# Altium schematic sheet CPUBoard_p3.SchDoc
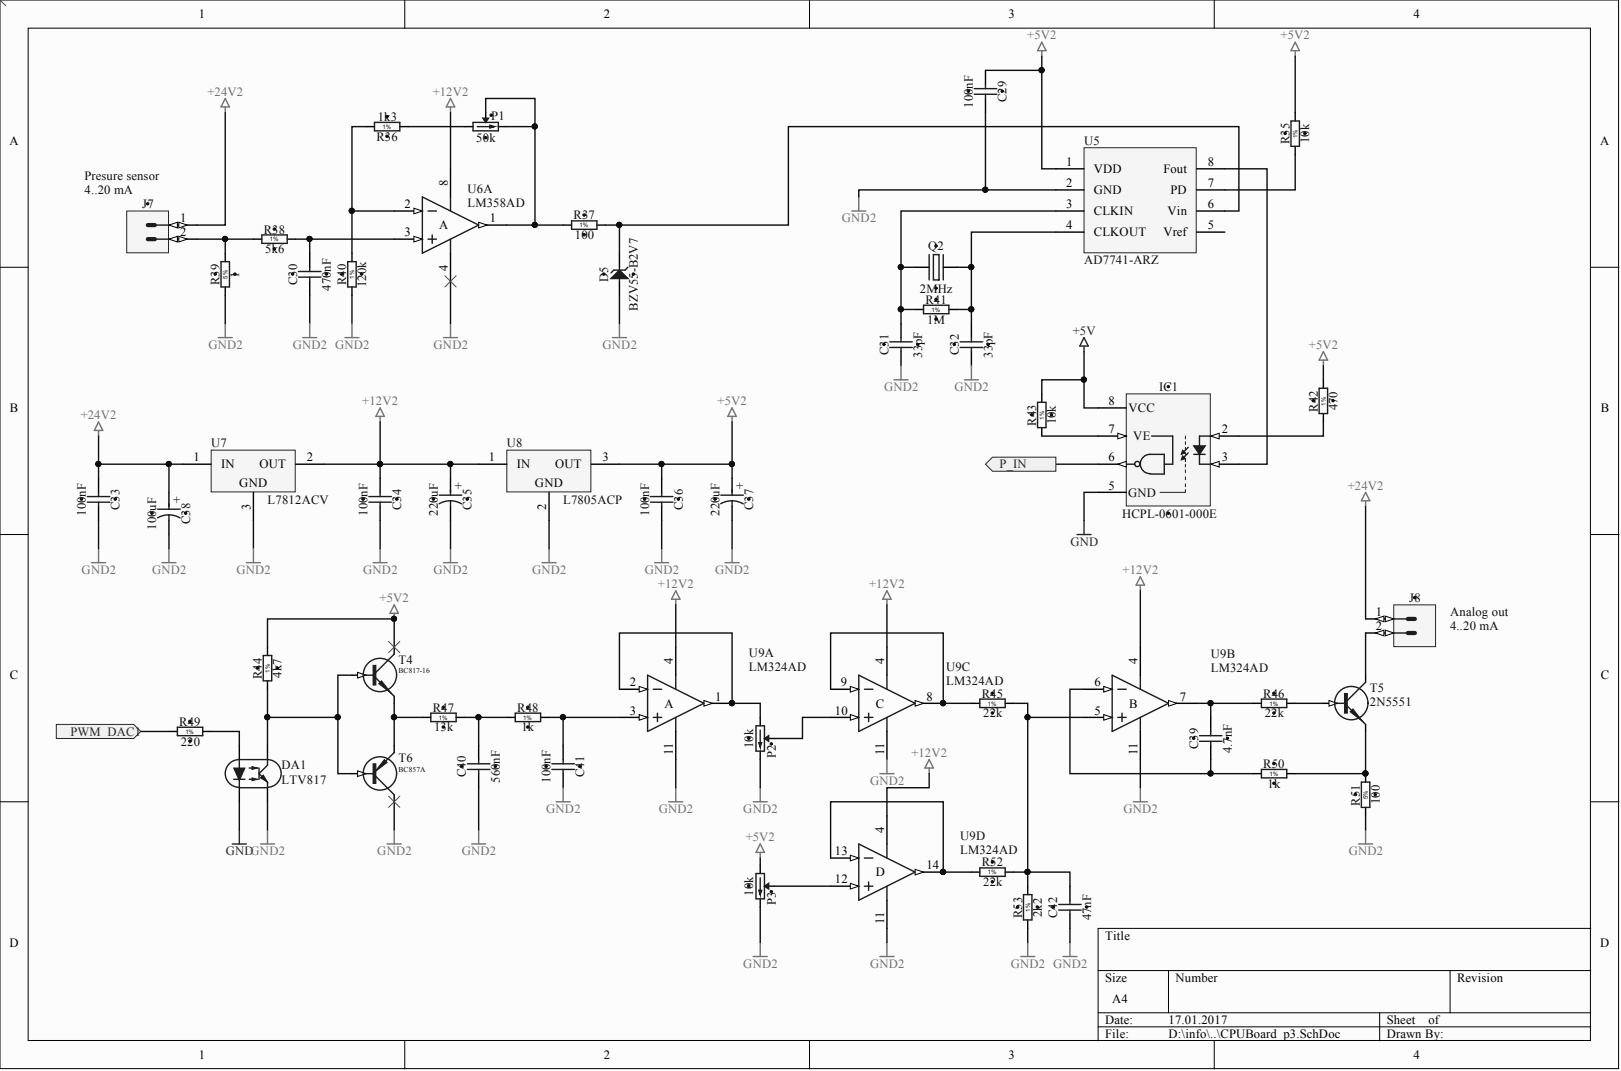
[diagram p0: CPUBoard_p3.SchDoc]

## Parts
C29  100nF  [CAPC2012X135N]
C30  470nF  [CAPC2012X110N]
C31  33pF  [CAPC2012X135N]
C32  33pF  [CAPC2012X135N]
C33  100nF  [CAPC2012X135N]
C34  100nF  [CAPC2012X135N]
C35  220uF  [CAPPR350-850X1300]
C36  100nF  [CAPC2012X135N]
C37  220uF  [CAPPR350-850X1300]
C38  100uF  [CAPPR500-1050X1750]
C39  4.7nF  [CAPC2012X135N]
C40  560nF  [CAPC2012X110N]
C41  100nF  [CAPC2012X135N]
C42  47nF  [CAPC2013X100N]
D5  BZV55-B2V7  [DIOMELF3515N]
DA1  LTV817  [DIL4-300a]
IC1  HCPL-0601-000E  [SOIC127P600X330-8N]
J7  KK®  [MOLEX_22-27-2021]  (value not drawn)
J8  KK®  [MOLEX_22-27-2021]  (value not drawn)
P1  50k  [TRIM_BOURNS_3296Y]
P2  10k  [TRIM_BOURNS_3296Y]
P3  10k  [TRIM_BOURNS_3296Y]
Q2  2MHz  [XTAL_HC-49/U-S]
R35  10k  [RESC2012X60N]
R36  1k3  [RESC2012X60N]
R37  100  [RESC2012X60N]
R38  5k6  [RESC2012X60N]
R39  1  [RESC3225X70N]
R40  120k  [RESC2012X60N]
R41  1M  [RESC2012X60N]
R42  470  [RESC2012X60N]
R43  10k  [RESC2012X60N]
R44  4k7  [RESC2012X60N]
R45  22k  [RESC2012X60N]
R46  22k  [RESC2012X60N]
R47  13k  [RESC2012X60N]
R48  1k  [RESC2012X60N]
R49  220  [RESC2012X60N]
R50  1k  [RESC2012X60N]
R51  100  [RESC3225X70N]
R52  22k  [RESC2012X60N]
R53  2k2  [RESC2012X60N]
T4  BC817-16  [SOT23]
T5  2N5551  [TO92C1]
T6  BC857A  [SOT23]
U5  AD7741-ARZ  [SOIC8 8S1_M]
U6  LM358AD  [SO8_N]  (part A)
U7  L7812ACV  [TO220ABN]
U8  L7805ACP  [TO220FP]
U9  LM324AD  [SO14_N]  (parts A,B,C,D)

## Ports
P_IN, PWM_DAC1

## Nets
+5V: IC1.8(VCC) R43.2
+5V2: C29.1 C36.1 C37.1 P3.1 R35.2 R42.2 R44.2 T4.3 U5.1(VDD) U8.3
+12V2: C34.1 C35.1 U6.8 U7.2 U8.1 U9.4
+24V2: C33.1 C38.1 J7.1 J8.1 U7.1
GND: DA1.2 IC1.5(GND)
GND2: C29.2 C30.1 C31.1 C32.1 C33.2 C34.2 C35.2 C36.2 C37.2 C38.2 C40.1 C41.2 C42.1 D5.2 DA1.3 P2.3 P3.3 R39.1 R40.1 R51.1 R53.1 T6.3 U5.2(GND) U6.4 U7.3 U8.2 U9.11
P_IN: IC1.6 ; port P_IN
PWM_DAC1: R49.1 ; port PWM_DAC1
NetC30_2: C30.2 R38.2 U6.3
NetC31_2: C31.2 Q2.1 R41.1 U5.3(CLKIN)
NetC32_2: C32.2 Q2.2 R41.2 U5.4(CLKOUT)
NetC39_1: C39.1 R50.1 U9.6
NetC39_2: C39.2 R46.1 U9.7
NetC40_2: C40.2 R47.2 R48.1
NetC41_1: C41.1 R48.2 U9.3
NetC42_2: C42.2 R45.2 R52.2 R53.2 U9.5
NetD5_1: D5.1 R37.2 U5.6(Vin)
NetDA1_1: DA1.1 R49.2
NetDA1_4: DA1.4 R44.1 T4.1 T6.1
NetIC1_2: IC1.2 R42.1
NetIC1_3: IC1.3 U5.8(Fout)
NetIC1_7: IC1.7(VE) R43.1
NetJ7_2: J7.2 R38.1 R39.2
NetJ8_2: J8.2 T5.1
NetP1_1: P1.1 R36.1
NetP1_2: P1.2 P1.3 R37.1 U6.1
NetP2_1: P2.1 U9.1 U9.2
NetP2_2: P2.2 U9.10
NetP3_2: P3.2 U9.12
NetR35_1: R35.1 U5.7(PD)
NetR36_2: R36.2 R40.2 U6.2
NetR45_1: R45.1 U9.8 U9.9
NetR46_2: R46.2 T5.2
NetR47_1: R47.1 T4.2 T6.2
NetR50_2: R50.2 R51.2 T5.3
NetR52_1: R52.1 U9.13 U9.14

Not connected: U5 5(Vref)

## Library data of the parts
C29 C33 C34 C36 C41 = CC0805_100NF_50V_10%_X7R: Non-polarised capacitor; Manufacturer: GENERIC; Manufacturer1 Part Number: B37941K5104K62; Part Description: SMD Multilayer Chip Ceramic Capacitor; Database Table Name: Capacitors SMD; TC: X7R; Tolerance: ±10%; Voltage: 50V; Case: 0805; Component Kind: Standard; Component Type: Standard; CreateDate: 03.12.2007; HelpURL: \\cern.ch\dfs\Applications\Altium\Datasheets\CC0805_X7R_EPCOS.pdf; LatestRevisionDate: 03.12.2007; Library Name: Capacitors.DbLib; Manufacturer1 ComponentHeight: 1.35mm; Manufacturer1 Example: EPCOS; Manufacturer Part Number: CC0805_100NF_50V_10%_X7R; Mounted: Yes; Part Number: CC0805_100NF_50V_10%_X7R; Pin Count: 2; SMD: Yes; Socket: No; Status: Preferred; Table: Capacitors SMD; Value: 100nF; Author: CERN DEM JLC
C30 C40 = CC0805_470NF_50V_10%_X7R: Non-polarised capacitor; Manufacturer: GENERIC; Manufacturer1 Part Number: C0805C474K5RACTU; Part Description: SMD Multilayer Chip Ceramic Capacitor; Database Table Name: Capacitors SMD; TC: X7R; Tolerance: ±10%; Voltage: 50V; Case: 0805; Component Kind: Standard; Component Type: Standard; CreateDate: 04.12.2013; HelpURL: \\cern.ch\dfs\Applications\Altium\Datasheets\CC0805_X7R_KEMET.pdf; LatestRevisionDate: 04.12.2013; Library Name: Capacitors.DbLib; Manufacturer1 ComponentHeight: 1.1mm; Manufacturer1 Example: KEMET; Manufacturer Part Number: CC0805_470NF_50V_10%_X7R; Mounted: Yes; Part Number: CC0805_470NF_50V_10%_X7R; Pin Count: 2; SMD: Yes; Socket: No; Status: Preferred; Table: Capacitors SMD; Author: CERN DEM JLC
  C30: Value: 470nF
  C40: Value: 560nF
C31 C32 = CC0805_33PF_50V_5%_NP0: Non-polarised capacitor; Manufacturer: GENERIC; Manufacturer1 Part Number: 223886115339; Part Description: SMD Multilayer Chip Ceramic Capacitor; Database Table Name: Capacitors SMD; TC: NP0; Tolerance: ±5%; Voltage: 50V; Case: 0805; Component Kind: Standard; Component Type: Standard; CreateDate: 03.12.2007; HelpURL: \\cern.ch\dfs\Applications\Altium\Datasheets\CC0805_NP0_PHYCOMP.pdf; LatestRevisionDate: 03.12.2007; Library Name: Capacitors.DbLib; Manufacturer1 ComponentHeight: 1.35mm; Manufacturer1 Example: PHYCOMP; Manufacturer Part Number: CC0805_33PF_50V_5%_NP0; Mounted: Yes; Part Number: CC0805_33PF_50V_5%_NP0; Pin Count: 2; SMD: Yes; Socket: No; Status: Preferred; Table: Capacitors SMD; Value: 33pF; Author: CERN DEM JLC
C35 C37 = CAER_220UF_25V_20%_RUBYCON_25YXF220M8X11.5: Polarised capacitor; Part Description: Radial Miniature, Aluminum Electrolytic Capacitor, (YXF Series); Database Table Name: Capacitors THD; Tolerance: ±20%; Voltage: 25V; Case: LS3.5 D8.5 x H13; ComponentHeight: 13mm; Component Kind: Standard; Component Type: Standard; CreateDate: 20.08.2009; HelpURL: \\cern.ch\dfs\Applications\Altium\Datasheets\CAER_RUBYCON_YXF.pdf; LatestRevisionDate: 17.09.2009; Library Name: Capacitors.DbLib; Manufacturer: RUBYCON; Manufacturer Part Number: 25YXF220M8X11.5; Mounted: Yes; Part Number: CAER_220UF_25V_20%_RUBYCON_25YXF220M8X11.5; Pin Count: 2; SMD: No; Socket: No; Status: None; Table: Capacitors THD; Value: 220uF; Author: CERN DEM JLC
C38 = CAER_100UF_63V_20%_500-1050X1750_LESR0R190: Polarised capacitor; Manufacturer: VISHAY BCCOMPONENTS; Manufacturer Part Number: MAL213668101E3 Series 136RVI; Part Description: Low ESR 0R190 Aluminum Electrolytic Capacitor; Database Table Name: Capacitors THD; Tolerance: ±20%; Voltage: 63V; Case: LS5 D10.5 x H17.5; ComponentHeight: 17.5mm; Component Kind: Standard; Component Type: Standard; CreateDate: 03.07.2008; HelpURL: \\cern.ch\dfs\Applications\Altium\Datasheets\CAER-LESR_VISHAY_136RVI.pdf; LatestRevisionDate: 16.03.2009; Library Name: Capacitors.DbLib; Mounted: Yes; Part Number: CAER_100UF_63V_20%_500-1050X1750_LESR0R190; Pin Count: 2; SMD: No; Socket: No; Status: Preferred; Table: Capacitors THD; Value: 100uF; Author: CERN DEM JLC
C39 = CC0805_4.7NF_50V_10%_X7R: Non-polarised capacitor; Manufacturer: GENERIC; Manufacturer1 Part Number: 223858615632; Part Description: SMD Multilayer Chip Ceramic Capacitor; Database Table Name: Capacitors SMD; TC: X7R; Tolerance: ±10%; Voltage: 50V; Case: 0805; Component Kind: Standard; Component Type: Standard; CreateDate: 03.12.2007; HelpURL: \\cern.ch\dfs\Applications\Altium\Datasheets\CC0805_X7R_PHYCOMP.pdf; LatestRevisionDate: 03.12.2007; Library Name: Capacitors.DbLib; Manufacturer1 ComponentHeight: 0.94mm; Manufacturer1 Example: PHYCOMP; Manufacturer Part Number: CC0805_4.7NF_50V_10%_X7R; Mounted: Yes; Part Number: CC0805_4.7NF_50V_10%_X7R; Pin Count: 2; SMD: Yes; Socket: No; Status: Preferred; Table: Capacitors SMD; Value: 4.7nF; Author: CERN DEM JLC
C42 = CC0805_47NF_50V_10%_X7R: Non-polarised capacitor; Manufacturer: GENERIC; Manufacturer1 Part Number: C0805F473K5RACTU; Part Description: SMD Multilayer Chip Ceramic Capacitor; Database Table Name: Capacitors SMD; TC: X7R; Tolerance: ±10%; Voltage: 50V; Case: 0805; Component Kind: Standard; Component Type: Standard; CreateDate: 04.12.2013; HelpURL: \\cern.ch\dfs\Applications\Altium\Datasheets\CC0805_X7R_KEMET.pdf; LatestRevisionDate: 04.12.2013; Library Name: Capacitors.DbLib; Manufacturer1 ComponentHeight: 1mm; Manufacturer1 Example: KEMET; Manufacturer Part Number: CC0805_47NF_50V_10%_X7R; Mounted: Yes; Part Number: CC0805_47NF_50V_10%_X7R; Pin Count: 2; SMD: Yes; Socket: No; Status: Preferred; Table: Capacitors SMD; Value: 47nF; Author: CERN DEM JLC
D5 = BZV55-B2V7: Zener Diode; Manufacturer: NXP SEMICONDUCTORS; Part Description: Voltage Regulator Diode; Database Table Name: Diodes; Power: 500mW; Voltage: 2V7; Case: SOD-80C; ComponentHeight: 1.6mm; Component Kind: Standard; Component Type: Standard; CreateDate: 06.05.2008; Device: BZV55-B2V7; HelpURL: \\cern.ch\dfs\Applications\Altium\Datasheets\BZV55.pdf; LatestRevisionDate: 06.05.2008; Library Name: ICs And Semiconductors.DbLib; Manufacturer Part Number: BZV55-B2V7; Mounted: Yes; PackageDescription: SOD80C, MELF Diode, 2-Leads, Body 3.5x1.5mm, IPC Medium Density; Part Number: BZV55-B2V7; Pin Count: 2; SMD: Yes; Socket: No; Table: Diodes; Author: CERN DEM JLC
IC1 = HCPL-0601-000E: Single Optocoupler; Manufacturer: AVAGO TECHNOLOGIES; Part Description: High CMR, High Speed TTL Compatible Optocouplers; Database Table Name: Optocouplers; Case: SOIC8; ComponentHeight: 3.3mm; Component Kind: Standard; Component Type: Standard; CreateDate: 08.04.2009; Device: HCPL-0601-000E; HelpURL: \\cern.ch\dfs\Applications\Altium\Datasheets\HCPL-0601-000E.pdf; LatestRevisionDate: 08.04.2009; Library Name: ICs And Semiconductors.DbLib; Manufacturer Part Number: HCPL-0601-000E; Mounted: Yes; PackageDescription: SOIC, 1.27mm pitch;8  pin, 4.00mm W X 5.00mm L, IPC Medium Density; Part Number: HCPL-0601-000E; Pin Count: 8; SMD: Yes; Socket: No; Table: Optocouplers; Author: CERN DEM JLC
J7 J8 = MOLEX_22-27-2021: Connector 2 Male; Part Description: 2.54mm (.100") Pitch KK® Wire-to-Board Header, Vertical, with Friction Lock, 2 Circuits, Tin (Sn) Plating; Database Table Name: MOLEX; ComponentHeight: 11.7mm; Component Kind: Standard; Component Type: Standard; CreateDate: 04.12.2008; Family: KK®; HelpURL: \\cern.ch\dfs\Applications\Altium\Datasheets\MOLEX_22-27-2021.pdf; LatestRevisionDate: 04.12.2008; Library Name: Connectors.DbLib; Manufacturer: MOLEX; Manufacturer Part Number: 22-27-2021; Mounted: Yes; Part Number: MOLEX_22-27-2021; Pin Count: 2; SMD: No; Socket: No; Status: None; Table: MOLEX; Author: CERN DEM JLC
P1 = TRIM_25T_50K_BOURNS_3296Y-1-503LF: Trimming Potentiometer; Manufacturer: BOURNS; Part Description: 25 Turn Trimming Potentiometer (Top Adjustement); Database Table Name: Potentiometers THD; Power: 0.5W; TC: ±100ppm/°C; Tolerance: ±10%; Case: 3296Y; ComponentHeight: 11.55mm; Component Kind: Standard; Component Type: Standard; CreateDate: 20.10.2008; HelpURL: \\cern.ch\dfs\Applications\Altium\Datasheets\TRIM_BOURNS_3296Y.pdf; LatestRevisionDate: 28.11.2012; Library Name: Resistors.DbLib; Manufacturer Part Number: 3296Y-1-503LF; Mounted: Yes; Part Number: TRIM_25T_50K_BOURNS_3296Y-1-503LF; Pin Count: 3; SMD: No; Socket: No; Table: Potentiometers THD; Value: 50k; Author: CERN DEM JLC
P2 P3 = TRIM_25T_10K_BOURNS_3296Y-1-103LF: Trimming Potentiometer; Manufacturer: BOURNS; Part Description: 25 Turn Trimming Potentiometer (Top Adjustement); Database Table Name: Potentiometers THD; Power: 0.5W; TC: ±100ppm/°C; Tolerance: ±10%; Case: 3296Y; ComponentHeight: 11.55mm; Component Kind: Standard; Component Type: Standard; CreateDate: 20.10.2008; HelpURL: \\cern.ch\dfs\Applications\Altium\Datasheets\TRIM_BOURNS_3296Y.pdf; LatestRevisionDate: 28.11.2012; Library Name: Resistors.DbLib; Manufacturer Part Number: 3296Y-1-103LF; Mounted: Yes; Part Number: TRIM_25T_10K_BOURNS_3296Y-1-103LF; Pin Count: 3; SMD: No; Socket: No; Table: Potentiometers THD; Value: 10k; Author: CERN DEM JLC
Q2 = XTAL_5MHZ_CITIZEN_HC49US5.000MABJ-UB: Quartz Crystal; Manufacturer: CITIZEN FINETECH MIYOTA CO; Part Description: Tolerance:± 30ppm, Stability:± 50ppm, -10°C to +60°C, 18pF, Quartz Crystal, HC-49/U-S Serie; Database Table Name: Crystals & Oscillators; ComponentHeight: 3.5mm; Component Kind: Standard; Component Type: Standard; CreateDate: 27.11.2012; HelpURL: \\cern.ch\dfs\Applications\Altium\Datasheets\XTAL_CITIZEN_HC-49-U-S.pdf; LatestRevisionDate: 27.11.2012; Library Name: ICs And Semiconductors.DbLib; Manufacturer Part Number: HC49US5.000MABJ-UB; Mounted: Yes; PackageDescription: HC-49/U-S Leaded Cristal, Body 11.5x4.66mm; Pitch 4.88mm; Part Number: XTAL_5MHZ_CITIZEN_HC49US5.000MABJ-UB; Pin Count: 2; SMD: No; Socket: No; Table: Crystals & Oscillators; Value: 2MHz; Author: CERN DEM JLC
R35 R43 = R0805_10K_1%_0.125W_100PPM: Resistor - 1%; Manufacturer: GENERIC; Manufacturer1 Part Number: NRC10F1002TRF; Part Description: General Purpose Thick Film Chip Resistor; Database Table Name: Resistors SMD; Power: 0.125W; TC: ±100ppm/°C; Case: 0805; Component Kind: Standard; Component Type: Standard; CreateDate: 03.12.2007; HelpURL: \\cern.ch\dfs\Applications\Altium\Datasheets\R0805_NIC_NRC.pdf; LatestRevisionDate: 13.03.2008; Library Name: Resistors.DbLib; Manufacturer1 ComponentHeight: 0.6mm; Manufacturer1 Example: NIC COMPONENT; Manufacturer Part Number: R0805_10K_1%_0.125W_100PPM; Mounted: Yes; Part Number: R0805_10K_1%_0.125W_100PPM; Pin Count: 2; SMD: Yes; Socket: No; Status: Preferred; Table: Resistors SMD; Tolerance: ±1%; Value: 10k; Author: CERN DEM JLC
R36 = R0805_1K3_1%_0.125W_100PPM: Resistor - 1%; Manufacturer: GENERIC; Manufacturer1 Part Number: NRC10F1301TRF; Part Description: General Purpose Thick Film Chip Resistor; Database Table Name: Resistors SMD; Power: 0.125W; TC: ±100ppm/°C; Case: 0805; Component Kind: Standard; Component Type: Standard; CreateDate: 03.12.2007; HelpURL: \\cern.ch\dfs\Applications\Altium\Datasheets\R0805_NIC_NRC.pdf; LatestRevisionDate: 13.03.2008; Library Name: Resistors.DbLib; Manufacturer1 ComponentHeight: 0.6mm; Manufacturer1 Example: NIC COMPONENT; Manufacturer Part Number: R0805_1K3_1%_0.125W_100PPM; Mounted: Yes; Part Number: R0805_1K3_1%_0.125W_100PPM; Pin Count: 2; SMD: Yes; Socket: No; Status: Preferred; Table: Resistors SMD; Tolerance: ±1%; Value: 1k3; Author: CERN DEM JLC
R37 = R0805_100R_1%_0.125W_100PPM: Resistor - 1%; Manufacturer: GENERIC; Manufacturer1 Part Number: NRC10F4700TRF; Part Description: General Purpose Thick Film Chip Resistor; Database Table Name: Resistors SMD; Power: 0.125W; TC: ±100ppm/°C; Case: 0805; Component Kind: Standard; Component Type: Standard; CreateDate: 03.12.2007; HelpURL: \\cern.ch\dfs\Applications\Altium\Datasheets\R0805_NIC_NRC.pdf; LatestRevisionDate: 13.03.2008; Library Name: Resistors.DbLib; Manufacturer1 ComponentHeight: 0.6mm; Manufacturer1 Example: NIC COMPONENT; Manufacturer Part Number: R0805_100R_1%_0.125W_100PPM; Mounted: Yes; Part Number: R0805_100R_1%_0.125W_100PPM; Pin Count: 2; SMD: Yes; Socket: No; Status: Preferred; Table: Resistors SMD; Tolerance: ±1%; Value: 100; Author: CERN DEM JLC
R38 = R0805_5K6_1%_0.125W_100PPM: Resistor - 1%; Manufacturer: GENERIC; Manufacturer1 Part Number: NRC10F5601TRF; Part Description: General Purpose Thick Film Chip Resistor; Database Table Name: Resistors SMD; Power: 0.125W; TC: ±100ppm/°C; Case: 0805; Component Kind: Standard; Component Type: Standard; CreateDate: 03.12.2007; HelpURL: \\cern.ch\dfs\Applications\Altium\Datasheets\R0805_NIC_NRC.pdf; LatestRevisionDate: 13.03.2008; Library Name: Resistors.DbLib; Manufacturer1 ComponentHeight: 0.6mm; Manufacturer1 Example: NIC COMPONENT; Manufacturer Part Number: R0805_5K6_1%_0.125W_100PPM; Mounted: Yes; Part Number: R0805_5K6_1%_0.125W_100PPM; Pin Count: 2; SMD: Yes; Socket: No; Status: Preferred; Table: Resistors SMD; Tolerance: ±1%; Value: 5k6; Author: CERN DEM JLC
R39 = R1210_51R_5%_0.5W_200PPM_PANASONIC_ERJP: Resistor - 0,1%; Manufacturer Part Number: ERJP14J510U; Part Description: Anti-Surge Thick Film Chip Resistor; Database Table Name: Resistors SMD; Power: 0.5W; TC: ±200ppm/°C; Tolerance: ±0.1%; Case: 1210; ComponentHeight: 0.7mm; Component Kind: Standard; Component Type: Standard; CreateDate: 21.01.2013; HelpURL: \\cern.ch\dfs\Applications\Altium\Datasheets\R1210_PANASONIC_ERJP14.pdf; LatestRevisionDate: 28.11.2012; Library Name: Resistors.DbLib; Manufacturer: PANASONIC; Mounted: Yes; Part Number: R1210_51R_5%_0.5W_200PPM_PANASONIC_ERJP; Pin Count: 2; SMD: Yes; Socket: No; Status: None; Table: Resistors SMD; Value: 1; Author: CERN DEM JLC
R40 = R0805_120K_1%_0.125W_100PPM: Resistor - 1%; Manufacturer: GENERIC; Manufacturer1 Part Number: NRC10F1203TRF; Part Description: General Purpose Thick Film Chip Resistor; Database Table Name: Resistors SMD; Power: 0.125W; TC: ±100ppm/°C; Case: 0805; Component Kind: Standard; Component Type: Standard; CreateDate: 03.12.2007; HelpURL: \\cern.ch\dfs\Applications\Altium\Datasheets\R0805_NIC_NRC.pdf; LatestRevisionDate: 13.03.2008; Library Name: Resistors.DbLib; Manufacturer1 ComponentHeight: 0.6mm; Manufacturer1 Example: NIC COMPONENT; Manufacturer Part Number: R0805_120K_1%_0.125W_100PPM; Mounted: Yes; Part Number: R0805_120K_1%_0.125W_100PPM; Pin Count: 2; SMD: Yes; Socket: No; Status: Preferred; Table: Resistors SMD; Tolerance: ±1%; Value: 120k; Author: CERN DEM JLC
R41 = R0805_1M_1%_0.125W_100PPM: Resistor - 1%; Manufacturer: GENERIC; Manufacturer1 Part Number: NRC10F1004TRF; Part Description: General Purpose Thick Film Chip Resistor; Database Table Name: Resistors SMD; Power: 0.125W; TC: ±100ppm/°C; Case: 0805; Component Kind: Standard; Component Type: Standard; CreateDate: 03.12.2007; HelpURL: \\cern.ch\dfs\Applications\Altium\Datasheets\R0805_NIC_NRC.pdf; LatestRevisionDate: 13.03.2008; Library Name: Resistors.DbLib; Manufacturer1 ComponentHeight: 0.6mm; Manufacturer1 Example: NIC COMPONENT; Manufacturer Part Number: R0805_1M_1%_0.125W_100PPM; Mounted: Yes; Part Number: R0805_1M_1%_0.125W_100PPM; Pin Count: 2; SMD: Yes; Socket: No; Status: Preferred; Table: Resistors SMD; Tolerance: ±1%; Value: 1M; Author: CERN DEM JLC
R42 = R0805_470R_1%_0.125W_100PPM: Resistor - 1%; Manufacturer: GENERIC; Manufacturer1 Part Number: NRC10F4700TRF; Part Description: General Purpose Thick Film Chip Resistor; Database Table Name: Resistors SMD; Power: 0.125W; TC: ±100ppm/°C; Case: 0805; Component Kind: Standard; Component Type: Standard; CreateDate: 03.12.2007; HelpURL: \\cern.ch\dfs\Applications\Altium\Datasheets\R0805_NIC_NRC.pdf; LatestRevisionDate: 13.03.2008; Library Name: Resistors.DbLib; Manufacturer1 ComponentHeight: 0.6mm; Manufacturer1 Example: NIC COMPONENT; Manufacturer Part Number: R0805_470R_1%_0.125W_100PPM; Mounted: Yes; Part Number: R0805_470R_1%_0.125W_100PPM; Pin Count: 2; SMD: Yes; Socket: No; Status: Preferred; Table: Resistors SMD; Tolerance: ±1%; Value: 470; Author: CERN DEM JLC
R44 = R0805_4K7_1%_0.125W_100PPM: Resistor - 1%; Manufacturer: GENERIC; Manufacturer1 Part Number: NRC10F4701TRF; Part Description: General Purpose Thick Film Chip Resistor; Database Table Name: Resistors SMD; Power: 0.125W; TC: ±100ppm/°C; Case: 0805; Component Kind: Standard; Component Type: Standard; CreateDate: 03.12.2007; HelpURL: \\cern.ch\dfs\Applications\Altium\Datasheets\R0805_NIC_NRC.pdf; LatestRevisionDate: 13.03.2008; Library Name: Resistors.DbLib; Manufacturer1 ComponentHeight: 0.6mm; Manufacturer1 Example: NIC COMPONENT; Manufacturer Part Number: R0805_4K7_1%_0.125W_100PPM; Mounted: Yes; Part Number: R0805_4K7_1%_0.125W_100PPM; Pin Count: 2; SMD: Yes; Socket: No; Status: Preferred; Table: Resistors SMD; Tolerance: ±1%; Value: 4k7; Author: CERN DEM JLC
R45 R46 R52 = R0805_22K_1%_0.125W_100PPM: Resistor - 1%; Manufacturer: GENERIC; Manufacturer1 Part Number: NRC10F2202TRF; Part Description: General Purpose Thick Film Chip Resistor; Database Table Name: Resistors SMD; Power: 0.125W; TC: ±100ppm/°C; Case: 0805; Component Kind: Standard; Component Type: Standard; CreateDate: 03.12.2007; HelpURL: \\cern.ch\dfs\Applications\Altium\Datasheets\R0805_NIC_NRC.pdf; LatestRevisionDate: 13.03.2008; Library Name: Resistors.DbLib; Manufacturer1 ComponentHeight: 0.6mm; Manufacturer1 Example: NIC COMPONENT; Manufacturer Part Number: R0805_22K_1%_0.125W_100PPM; Mounted: Yes; Part Number: R0805_22K_1%_0.125W_100PPM; Pin Count: 2; SMD: Yes; Socket: No; Status: Preferred; Table: Resistors SMD; Tolerance: ±1%; Value: 22k; Author: CERN DEM JLC
R47 = R0805_13K_1%_0.125W_100PPM: Resistor - 1%; Manufacturer: GENERIC; Manufacturer1 Part Number: NRC10F1302TRF; Part Description: General Purpose Thick Film Chip Resistor; Database Table Name: Resistors SMD; Power: 0.125W; TC: ±100ppm/°C; Case: 0805; Component Kind: Standard; Component Type: Standard; CreateDate: 03.12.2007; HelpURL: \\cern.ch\dfs\Applications\Altium\Datasheets\R0805_NIC_NRC.pdf; LatestRevisionDate: 13.03.2008; Library Name: Resistors.DbLib; Manufacturer1 ComponentHeight: 0.6mm; Manufacturer1 Example: NIC COMPONENT; Manufacturer Part Number: R0805_13K_1%_0.125W_100PPM; Mounted: Yes; Part Number: R0805_13K_1%_0.125W_100PPM; Pin Count: 2; SMD: Yes; Socket: No; Status: Preferred; Table: Resistors SMD; Tolerance: ±1%; Value: 13k; Author: CERN DEM JLC
R48 R50 = R0805_1K_1%_0.125W_100PPM: Resistor - 1%; Manufacturer: GENERIC; Manufacturer1 Part Number: NRC10F1001TRF; Part Description: General Purpose Thick Film Chip Resistor; Database Table Name: Resistors SMD; Power: 0.125W; TC: ±100ppm/°C; Case: 0805; Component Kind: Standard; Component Type: Standard; CreateDate: 03.12.2007; HelpURL: \\cern.ch\dfs\Applications\Altium\Datasheets\R0805_NIC_NRC.pdf; LatestRevisionDate: 13.03.2008; Library Name: Resistors.DbLib; Manufacturer1 ComponentHeight: 0.6mm; Manufacturer1 Example: NIC COMPONENT; Manufacturer Part Number: R0805_1K_1%_0.125W_100PPM; Mounted: Yes; Part Number: R0805_1K_1%_0.125W_100PPM; Pin Count: 2; SMD: Yes; Socket: No; Status: Preferred; Table: Resistors SMD; Tolerance: ±1%; Value: 1k; Author: CERN DEM JLC
R49 = R0805_220R_1%_0.125W_100PPM: Resistor - 1%; Manufacturer: GENERIC; Manufacturer1 Part Number: NRC10F2200TRF; Part Description: General Purpose Thick Film Chip Resistor; Database Table Name: Resistors SMD; Power: 0.125W; TC: ±100ppm/°C; Case: 0805; Component Kind: Standard; Component Type: Standard; CreateDate: 03.12.2007; HelpURL: \\cern.ch\dfs\Applications\Altium\Datasheets\R0805_NIC_NRC.pdf; LatestRevisionDate: 13.03.2008; Library Name: Resistors.DbLib; Manufacturer1 ComponentHeight: 0.6mm; Manufacturer1 Example: NIC COMPONENT; Manufacturer Part Number: R0805_220R_1%_0.125W_100PPM; Mounted: Yes; Part Number: R0805_220R_1%_0.125W_100PPM; Pin Count: 2; SMD: Yes; Socket: No; Status: Preferred; Table: Resistors SMD; Tolerance: ±1%; Value: 220; Author: CERN DEM JLC
R51 = R1210_270R_5%_0.5W_200PPM_PANASONIC_ERJP: Resistor - 0.1%; Manufacturer Part Number: ERJP14J271U; Part Description: Anti-Surge Thick Film Chip Resistor; Part Number: R1210_100R_5%_0.5W_200PPM_PANASONIC_ERJP; Database Table Name: Resistors SMD; Power: 0.5W; TC: ±200ppm/°C; Case: 1210; ComponentHeight: 0.7mm; Component Kind: Standard; Component Type: Standard; CreateDate: 21.01.2013; HelpURL: \\cern.ch\dfs\Applications\Altium\Datasheets\R1210_PANASONIC_ERJP14.pdf; LatestRevisionDate: 26.10.2011; Library Name: Resistors.DbLib; Manufacturer: PANASONIC; Mounted: Yes; Pin Count: 2; SMD: Yes; Socket: No; Status: None; Table: Resistors SMD; Tolerance: ±0.1%; Value: 100; Author: CERN DEM JLC
R53 = R0805_2K2_1%_0.125W_100PPM: Resistor - 1%; Manufacturer: GENERIC; Manufacturer1 Part Number: NRC10F2201TRF; Part Description: General Purpose Thick Film Chip Resistor; Database Table Name: Resistors SMD; Power: 0.125W; TC: ±100ppm/°C; Case: 0805; Component Kind: Standard; Component Type: Standard; CreateDate: 03.12.2007; HelpURL: \\cern.ch\dfs\Applications\Altium\Datasheets\R0805_NIC_NRC.pdf; LatestRevisionDate: 13.03.2008; Library Name: Resistors.DbLib; Manufacturer1 ComponentHeight: 0.6mm; Manufacturer1 Example: NIC COMPONENT; Manufacturer Part Number: R0805_2K2_1%_0.125W_100PPM; Mounted: Yes; Part Number: R0805_2K2_1%_0.125W_100PPM; Pin Count: 2; SMD: Yes; Socket: No; Status: Preferred; Table: Resistors SMD; Tolerance: ±1%; Value: 2k2; Author: CERN DEM JLC
T4 = BC817-16: SMD-SOT23; Component Kind: Standard; Library Name: BJT.IntLib; Price: 0.031; Signal Integrity: Q; Simulation: BC817-16
T5 = 2N5551: 150V 0.5A 100MHz 6p (10V); Component Kind: Standard; Library Name: BJT.IntLib; Price: 0.083; Signal Integrity: Q; Simulation: Q2N5551
T6 = BC857A: SMD-SOT23; Component Kind: Standard; Library Name: BJT.IntLib; Price: 0.04; Signal Integrity: Q; Simulation: BC857A
U6 = LM358AD: Low-Power Dual Operational Amplifier; Code_IPC: SOIC127P600-8; Code_JEDEC: MS-012-AA; Component Kind: Standard; ComponentLink1Description: Manufacturer Link; ComponentLink1URL: http://www.st.com/; ComponentLink2Description: Datasheet; ComponentLink2URL: http://www.st.com/stonline/products/literature/ds/2163/lm358.pdf; DatasheetVersion: Jun-1998; LatestRevisionDate: 13-Apr-2006; LatestRevisionNote: IPC-7351 Footprint Added.; Library Name: ST Operational Amplifier.IntLib; PackageDescription: 8-Pin Small Outline Integrated Circuit 1.27 mm Pitch; PackageReference: SO8; PackageVersion: Dec-2002; PCB3D: SO8; Published: 24-Aug-2001; Simulation: LM358; Publisher: Altium Limited
U7 = L7812ACV: Precision 1A Regulator; Component Kind: Standard; ComponentLink1Description: Manufacturer Link; ComponentLink1URL: http://www.st.com/; ComponentLink2Description: Datasheet; ComponentLink2URL: http://www.st.com/stonline/products/literature/ds/2144/l7805ab.pdf; DatasheetVersion: Nov-1999; LatestRevisionDate: 17-Jul-2002; LatestRevisionNote: Re-released for DXP Platform.; Library Name: ST Power Mgt Voltage Regulator.IntLib; PackageDescription: TO, Thru-Hole, Vertical, Heatsink Mounted; 3 In-Line Leads; Pitch 2.54 mm; Pin sequence 1, 3, 2; PackageReference: TO220ABN; PackageVersion: Jun-1998; Published: 24-Aug-2001; Publisher: Altium Limited
U8 = L7805ACP: Positive Voltage Regulator, 5V, 3-Pin TO-220FP; Component Kind: Standard; ComponentLink1Description: Manufacturer URL; ComponentLink1URL: http://www.st.com; ComponentLink2Description: Datasheet; ComponentLink2URL: http://www.st.com/internet/com/TECHNICAL_RESOURCES/TECHNICAL_LITERATURE/DATASHEET/CD00000444.pdf; DatasheetVersion: Nov-2011; Library Name: STMicroelectronics Power Management Linear Regulator.IntLib; PackageDescription: TO, Thru-Hole, Vertical, Heatsink Mounted; 3 In-Line Leads; Pitch 2.54 mm; PackageReference: TO220FP; PackageVersion: 2011; PartNumber: L7805ACP
U9 = LM324AD: Low-Power Quad Operational Amplifier; Code_IPC: SOIC127P600-14; Component Kind: Standard; ComponentLink1Description: Manufacturer Link; ComponentLink1URL: http://www.st.com/; ComponentLink2Description: Datasheet; ComponentLink2URL: http://www.st.com/stonline/products/literature/ds/4797/lm224a.pdf; DatasheetVersion: Nov-1997; LatestRevisionDate: 13-Apr-2006; LatestRevisionNote: IPC-7351 Footprint Added.; Library Name: ST Operational Amplifier.IntLib; PackageDescription: 14-Pin Small Outline Integrated Circuit 1.27 mm Pitch; PackageReference: SO14; PackageVersion: Sep-1998; PCB3D: SO14; Published: 24-Aug-2001; Simulation: LM324; Publisher: Altium Limited
DA1 = LTV817: Component Kind: Standard; Price: 0.28; Simulation: PC817; Library Name: Opto Coupler.IntLib
U5 = AD7741-ARZ: Component Kind: Standard; Description: AD7741-ARZ; Library Name: PowerLib.SchLib
